# Altium schematic sheet ESP_CAM_PRO_v1.4.SchDoc
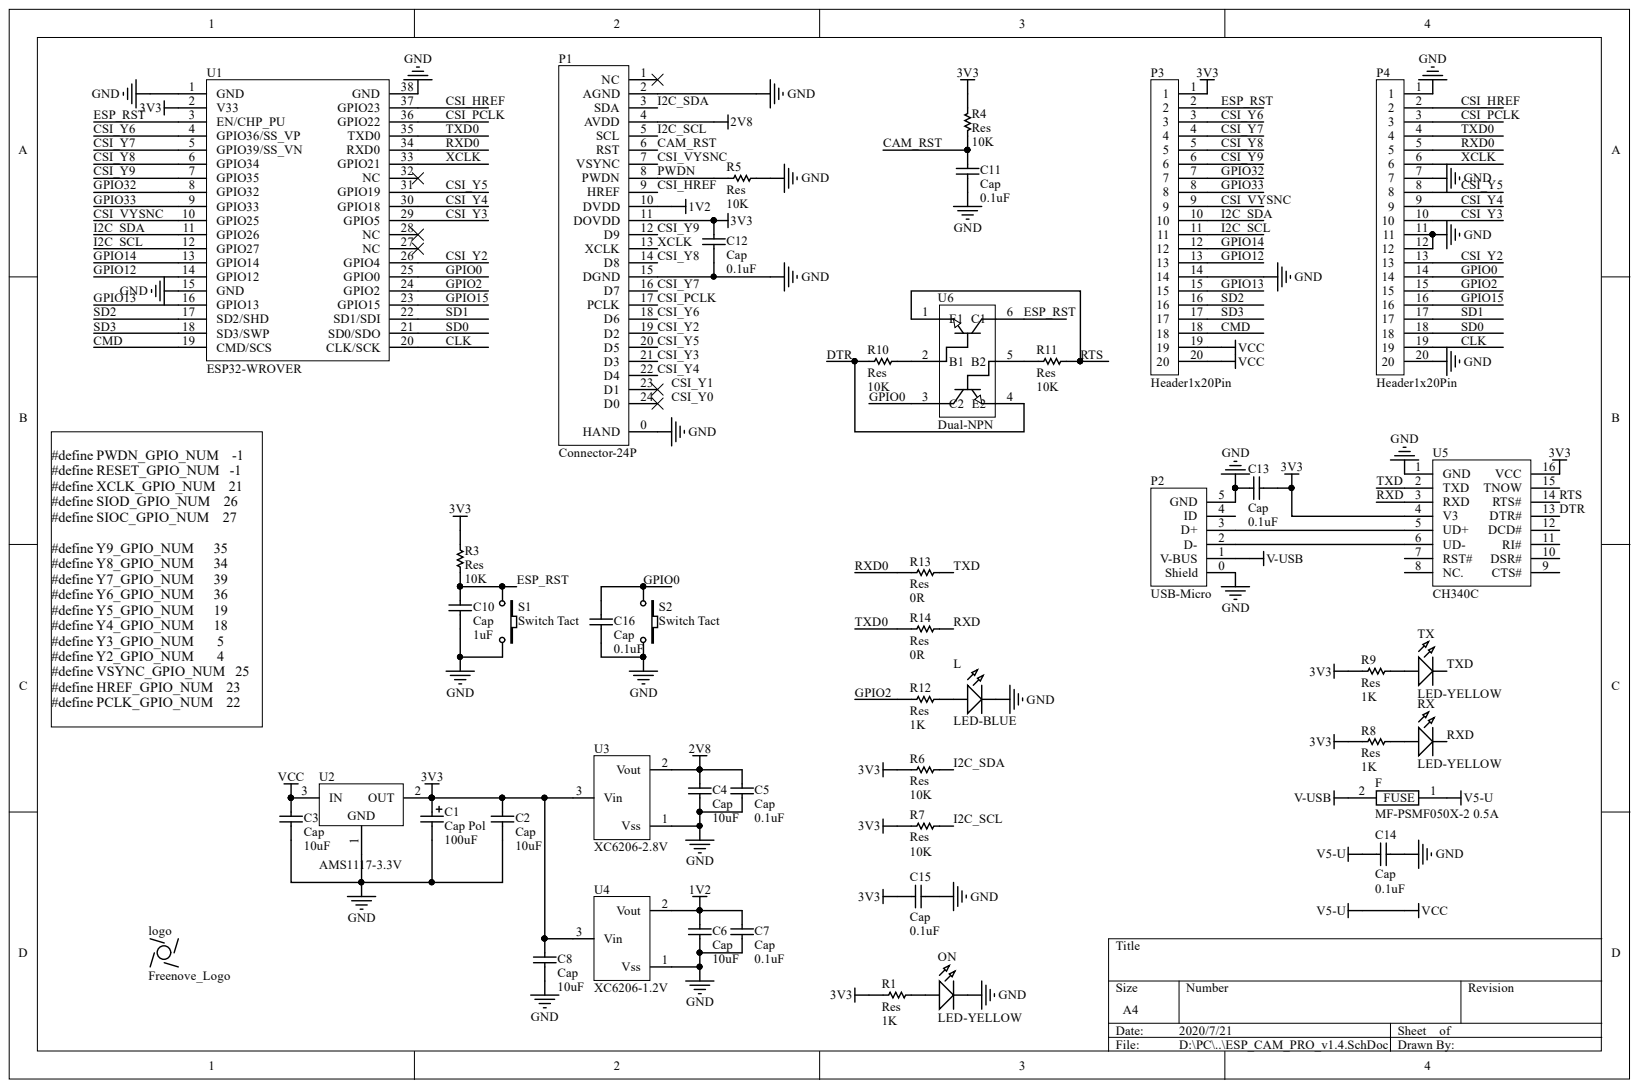
[diagram p0: ESP_CAM_PRO_v1.4.SchDoc]

## Parts
C1  Cap Pol  value 100uF  [cp1210]
C2  Cap  value 10uF  [c0603]
C3  Cap  value 10uF  [c0603]
C4  Cap  value 10uF  [c0603]
C5  Cap  value 0.1uF  [c0402]
C6  Cap  value 10uF  [c0603]
C7  Cap  value 0.1uF  [c0402]
C8  Cap  value 10uF  [c0603]
C10  Cap  value 1uF  [c0402]
C11  Cap  value 0.1uF  [c0402]
C12  Cap  value 0.1uF  [c0402]
C13  Cap  value 0.1uF  [c0402]
C14  Cap  value 0.1uF  [c0402]
C15  Cap  value 0.1uF  [c0402]
C16  Cap  value 0.1uF  [c0402]
F  MF-PSMF050X-2 0.5A  [c0805]
L  LED-BLUE  [d0603]
logo  Freenove_Logo  [FreeNove_logo_800mil]
ON  LED-YELLOW  [d0603]
P1  Connector-24P  [FPC-0.5-24P-下接翻盖]
P2  USB-Micro  [USB Micro Socket smt]
P3  Header1x20Pin  [Header20Pin]
P4  Header1x20Pin  [Header20Pin]
R1  Res  value 1K  [r0402]
R3  Res  value 10K  [r0402]
R4  Res  value 10K  [r0402]
R5  Res  value 10K  [r0402]
R6  Res  value 10K  [r0402]
R7  Res  value 10K  [r0402]
R8  Res  value 1K  [r0402]
R9  Res  value 1K  [r0402]
R10  Res  value 10K  [r0402]
R11  Res  value 10K  [r0402]
R12  Res  value 1K  [r0402]
R13  Res  value 0R  [r0402]
R14  Res  value 0R  [r0402]
RX  LED-YELLOW  [d0603]
S1  Switch Tact  [Key_SMT_3x4]
S2  Switch Tact  [Key_SMT_3x4]
TX  LED-YELLOW  [d0603]
U1  ESP32-WROVER  [ESP32-WROVER]
U2  AMS1117-3.3V  [SOT-223]
U3  XC6206-2.8V  [SOT23-M]
U4  XC6206-1.2V  [SOT23-M]
U5  CH340C  [SOP16]
U6  Dual-NPN  [SOT-363]

## Nets
1V2: C6.2 C7.2 P1.10(DVDD) U4.2
2V8: C4.2 C5.2 P1.4(AVDD) U3.2
3V3: C1.1 C2.2 C8.2 C12.2 C13.1 C15.2 P1.11(DOVDD) P3.1 R1.1 R3.2 R4.2 R6.1 R7.1 R8.2 R9.2 U1.2(V33) U2.2 U3.3 U4.3 U5.4(V3) U5.16(VCC)
GND: C1.2 C2.1 C3.1 C4.1 C5.1 C6.1 C7.1 C8.1 C10.1 C11.1 C12.1 C13.2 C14.1 C15.1 C16.2 L.2 ON.2 P1.0(HAND) P1.2(AGND) P1.15(DGND) P2.0(Shield) P2.5(GND) P3.14 P4.1 P4.7 P4.11 P4.12 P4.20 R5.1 S1.2 S2.2 U1.1(GND) U1.15(GND) U1.38(GND) U2.1 U3.1 U4.1 U5.1(GND)
V5-U: C3.2 C14.2 F.1 P3.19 P3.20 U2.3
V-USB: F.2 P2.1(V-BUS)
CAM_RST: C11.2 P1.6(RST) R4.1
CLK: P4.19 U1.20(CLK/SCK)
CMD: P3.18 U1.19(CMD/SCS)
CSI_HREF: P1.9(HREF) P4.2 U1.37(GPIO23)
CSI_PCLK: P1.17(PCLK) P4.3 U1.36(GPIO22)
CSI_VYSNC: P1.7(VSYNC) P3.9 U1.10(GPIO25)
CSI_Y2: P1.19(D2) P4.13 U1.26(GPIO4)
CSI_Y3: P1.21(D3) P4.10 U1.29(GPIO5)
CSI_Y4: P1.22(D4) P4.9 U1.30(GPIO18)
CSI_Y5: P1.20(D5) P4.8 U1.31(GPIO19)
CSI_Y6: P1.18(D6) P3.3 U1.4(GPIO36/SS_VP)
CSI_Y7: P1.16(D7) P3.4 U1.5(GPIO39/SS_VN)
CSI_Y8: P1.14(D8) P3.5 U1.6(GPIO34)
CSI_Y9: P1.12(D9) P3.6 U1.7(GPIO35)
DTR: R10.2 U5.13(DTR#) U6.4(E2)
ESP_RST: C10.2 P3.2 R3.1 S1.1 U1.3(EN/CHP_PU) U6.6(C1)
GPIO0: C16.1 P4.14 S2.1 U1.25(GPIO0) U6.3(C2)
GPIO2: P4.15 R12.2 U1.24(GPIO2)
GPIO12: P3.13 U1.14(GPIO12)
GPIO13: P3.15 U1.16(GPIO13)
GPIO14: P3.12 U1.13(GPIO14)
GPIO15: P4.16 U1.23(GPIO15)
GPIO32: P3.7 U1.8(GPIO32)
GPIO33: P3.8 U1.9(GPIO33)
I2C_SCL: P1.5(SCL) P3.11 R7.2 U1.12(GPIO27)
I2C_SDA: P1.3(SDA) P3.10 R6.2 U1.11(GPIO26)
PWDN: P1.8(PWDN) R5.2
RTS: R11.1 U5.14(RTS#) U6.1(E1)
RXD: R14.1 RX.2 U5.3(RXD)
RXD0: P4.5 R13.2 U1.34(RXD0)
SD0: P4.18 U1.21(SD0/SDO)
SD1: P4.17 U1.22(SD1/SDI)
SD2: P3.16 U1.17(SD2/SHD)
SD3: P3.17 U1.18(SD3/SWP)
TXD: R13.1 TX.2 U5.2(TXD)
TXD0: P4.4 R14.2 U1.35(TXD0)
XCLK: P1.13(XCLK) P4.6 U1.33(GPIO21)
NetL_1: L.1 R12.1
NetON_1: ON.1 R1.2
NetP2_2: P2.2(D-) U5.6(UD-)
NetP2_3: P2.3(D+) U5.5(UD+)
NetR8_1: R8.1 RX.1
NetR9_1: R9.1 TX.1
NetR10_1: R10.1 U6.2(B1)
NetR11_2: R11.2 U6.5(B2)
CSI_Y0:
CSI_Y1:

Not connected: P1 1(NC) 23(D1) 24(D0); P2 4(ID); U1 27(NC) 28(NC) 32(NC); U5 7(RST#) 8(NC.) 9(CTS#) 10(DSR#) 11(RI#) 12(DCD#) 15(TNOW)

## Library data of the parts
C13 = Cap: Value: 0.1uF; Component Kind: Standard; Library Name: Customer_Library.SchLib
F = Fuse: Component Kind: Standard; Library Name: MyLib.SchLib
L ON RX TX = LED: Component Kind: Standard; Library Name: Customer_Library.SchLib
logo = Freenove_Logo: logo,无实物器件，; Component Kind: Standard; Library Name: MyLib.SchLib
P1 = Connector-FPC-0.5-24P: OV2640,Connector-FPC-0.5-24P,翻盖下接; Component Kind: Standard; Library Name: MyLib.SchLib
P2 = USB-Micro: USB-Micro-famale; Component Kind: Standard; Library Name: MyLib.SchLib
P3 P4 = Header1x20Pin: Header1*20Pin,2.54mm; Component Kind: Standard; Library Name: MyLib.SchLib
R5 R6 R11 = Res: Value: 10K; Component Kind: Standard; Library Name: Customer_Library.SchLib
R13 = Res: Value: 0R; Component Kind: Standard; Library Name: Customer_Library.SchLib
U2 = AMS1117: Component Kind: Standard; Library Name: Customer_Library.SchLib
U3 U4 = LDO-SOT23: Component Kind: Standard; Description: LDO-SOT23; Library Name: MyLib.SchLib
U5 = CH340B: Component Kind: Standard; Description: CH340B; Library Name: MyLib.SchLib
C1 = Cap Pol: Component Kind: Standard; Library Name: Customer_Library.SchLib
C2-C8 C10-C12 C14-C16 = Cap: Component Kind: Standard; Library Name: Customer_Library.SchLib
R1 R3 R4 R7-R10 R12 R14 = Res: Component Kind: Standard; Library Name: Customer_Library.SchLib
S1 S2 = Switch Tact: Component Kind: Standard; Library Name: Customer_Library.SchLib
U1 = ESP32-WROVER: Component Kind: Standard; Description: ESP32-WROVER; Library Name: MyLib.SchLib
U6 = Dual-NPN: Component Kind: Standard; Description: Dual-NPN; Library Name: MyLib.SchLib
